# Altium schematic sheet Supressor.SchDoc
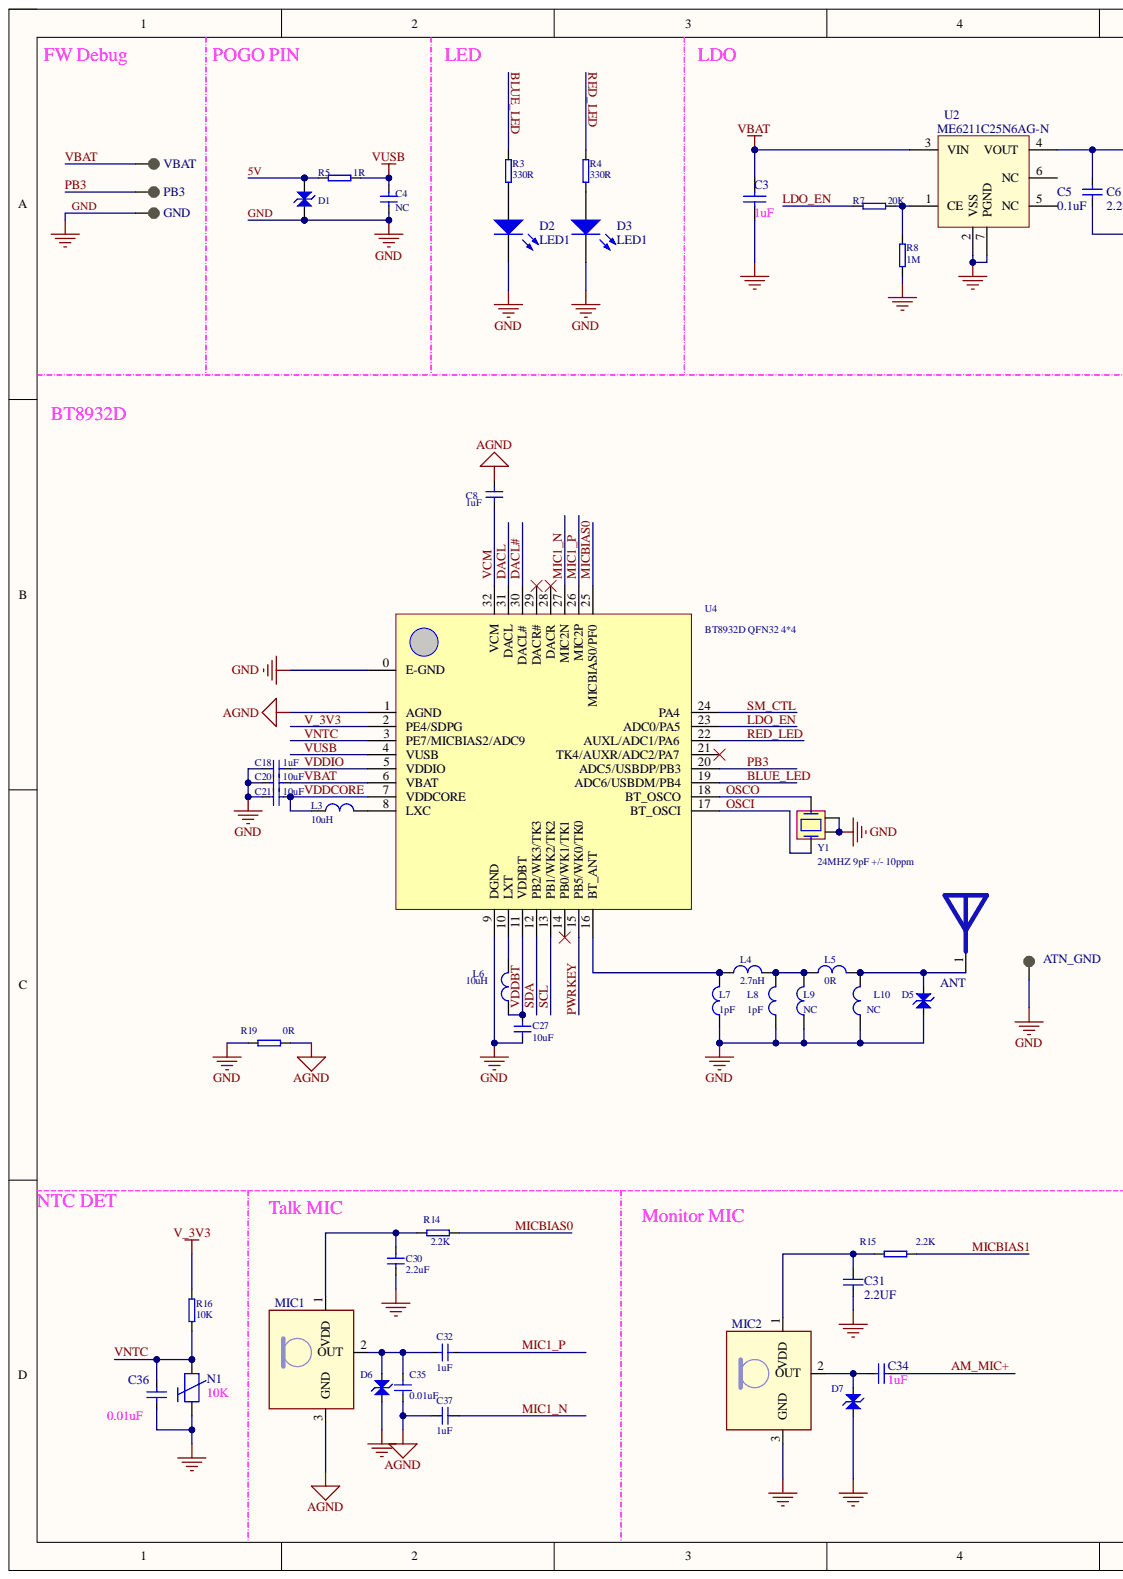
[diagram p0: Supressor.SchDoc, left]
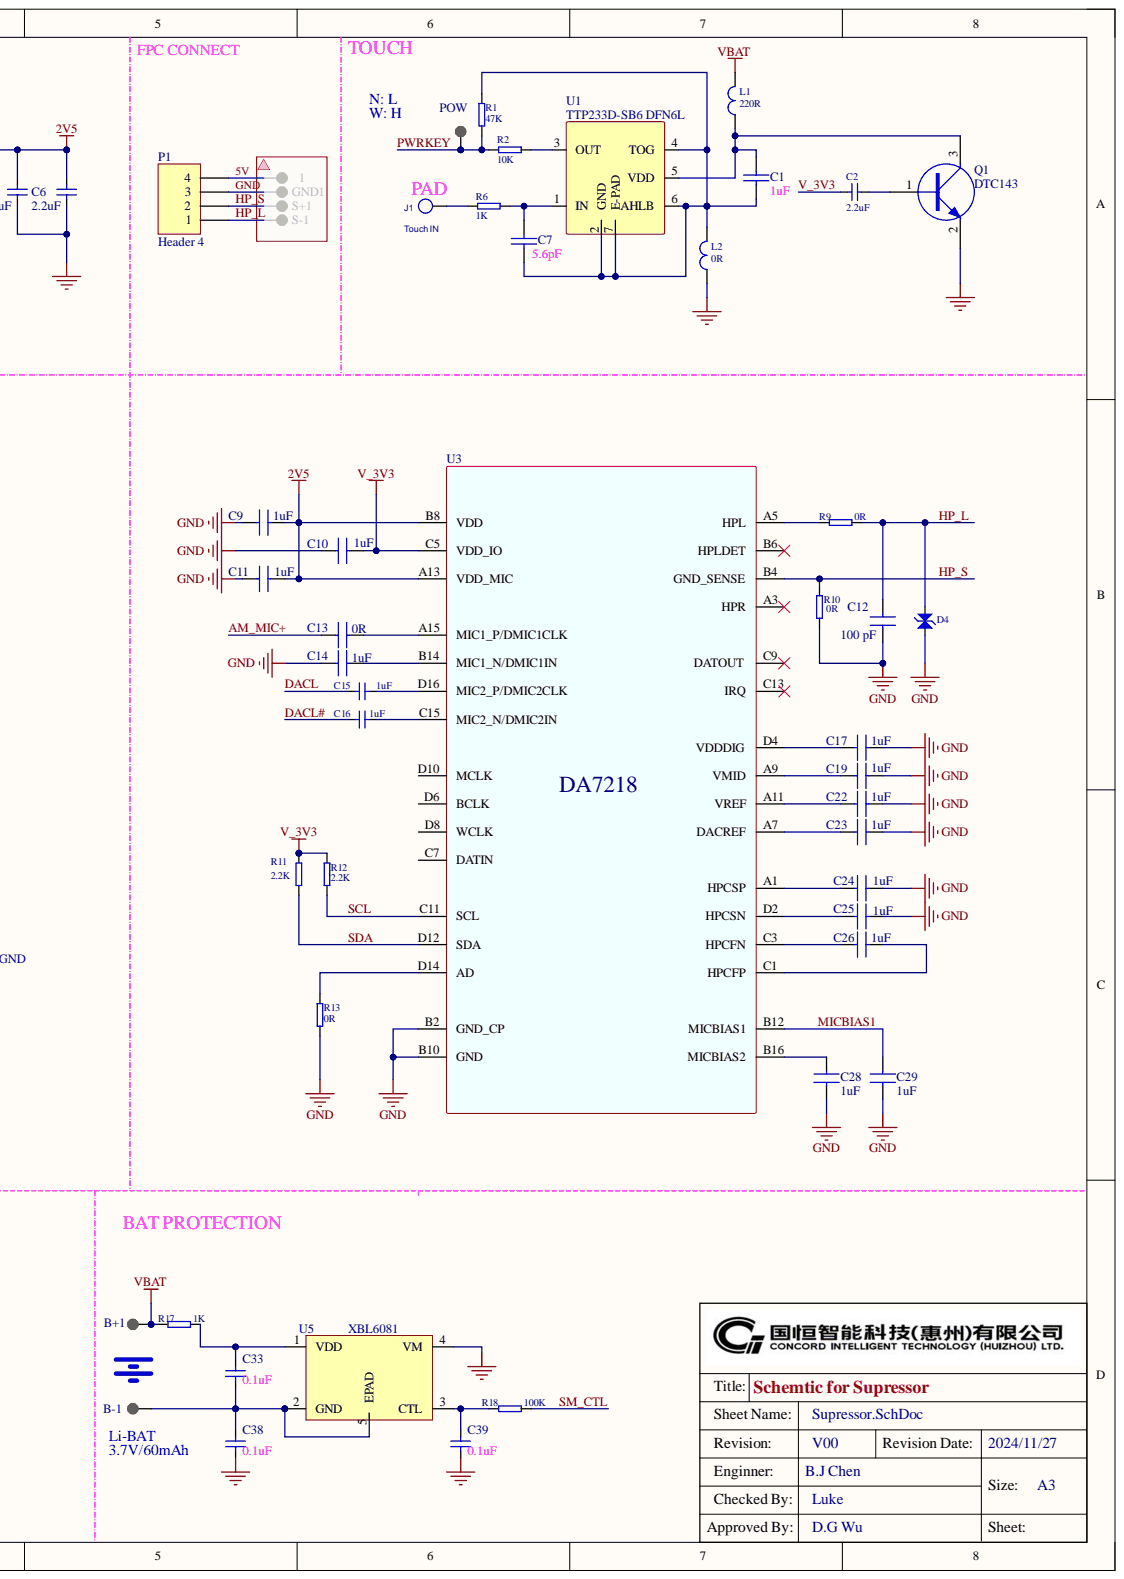
[diagram p1: Supressor.SchDoc, right]

## Parts
ANT  pogopin  [POGO PING-1.5]  (value not drawn)
ATN_GND  pogopin  [POGO PING-1.5]  (value not drawn)
B+1  TP  [TP-1.0]  (value not drawn)
B-1  TP  [TP-1.0]  (value not drawn)
C1  1uF  [CMA0201_CAP]
C2  2.2uF  [CMA0201_CAP]
C3  value 1uF  [CMA0201_CAP]  (hidden comment: 102-1105620B2)
C4  NC  [CMA0201_CAP]
C5  0.1uF 0201  [CMA0201_CAP]  (value not drawn)
C6  2.2uF 0201  [CMA0201_CAP]  (value not drawn)
C7  5.6pF  [CMA0201_CAP]
C8  1uF  [CMA0201_CAP]
C9  value 1uF  [CMA0201_CAP]  (hidden comment: 102-1105710B2)
C10  Part Number: 1uF  [CMA0201_CAP]  (hidden comment: 102-1105710B2)
C11  Part Number: 1uF  [CMA0201_CAP]  (hidden comment: 102-1105710B2)
C12  100 pF  [CMA0201_CAP]
C13  Part Number: 0R  [CMA0201_CAP]  (hidden comment: 102-1105710B2)
C14  Part Number: 1uF  [CMA0201_CAP]  (hidden comment: 102-1105710B2)
C15  1uF  [CMA0201_CAP]
C16  1uF  [CMA0201_CAP]
C17  Part Number: 1uF  [CMA0201_CAP]  (hidden comment: 102-1105710B2)
C18  1uF  [CMA0201_CAP]
C19  Part Number: 1uF  [CMA0201_CAP]  (hidden comment: 102-1105710B2)
C20  10uF  [CMA0402_CAP]
C21  10uF  [CMA0402_CAP]
C22  Part Number: 1uF  [CMA0201_CAP]  (hidden comment: 102-1105710B2)
C23  Part Number: 1uF  [CMA0201_CAP]  (hidden comment: 102-1105710B2)
C24  Part Number: 1uF  [CMA0201_CAP]  (hidden comment: 102-1105710B2)
C25  Part Number: 1uF  [CMA0201_CAP]  (hidden comment: 102-1105710B2)
C26  Part Number: 1uF  [CMA0201_CAP]  (hidden comment: 102-1105710B2)
C27  10uF  [CMA0402_CAP]
C28  Part Number: 1uF  [CMA0201_CAP]  (hidden comment: 102-1105710B2)
C29  Part Number: 1uF  [CMA0201_CAP]  (hidden comment: 102-1105710B2)
C30  2.2uF  [CMA0201_CAP]
C31  2.2UF  [CMA0201_CAP]
C32  1uF  [CMA0201_CAP]
C33  0.1uF  [CMA0201_CAP]
C34  1uF  [CMA0201_CAP]
C35  0.01uF  [CMA0201_CAP]
C36  0.01uF  [CMA0201_CAP]
C37  1uF  [CMA0201_CAP]
C38  0.1uF  [CMA0201_CAP]
C39  0.1uF  [CMA0201_CAP]
D1  104-0006210A2  [SOD-882(ESD)]  (value not drawn)
D2  LED1  [0201-LED-BLUE]
D3  LED1  [0201-LED -RED]
D4  104-0006210A2  [SOD-882(ESD)]  (value not drawn)
D5  104-0006210A2  [SOD-882(ESD)]  (value not drawn)
D6  104-0006210A2  [SOD-882(ESD)]  (value not drawn)
D7  104-0006210A2  [SOD-882(ESD)]  (value not drawn)
GND  TP  [TP-0.6]  (value not drawn)
J1  Touch IN  [POGO PING-1.5]
L1  220R  [CMA0402_RES]
L2  0R  [CMA0201_IND]
L3  10uH  [CMA0603_IND]
L4  2.7nH  [CMA0201_IND]
L5  0R  [CMA0201_IND]
L6  10uH  [CMA0603_IND]
L7  1pF  [CMA0201_IND]
L8  1pF  [CMA0201_IND]
L9  NC  [CMA0201_IND]
L10  NC  [CMA0201_IND]
MIC1  MIC -38dB  [MIC2718B381YR6-ANC]  (value not drawn)
MIC2  MIC -38dB  [MIC2718B381YR6-ANC]  (value not drawn)
N1  10K  [CMA0402_RES - silk]
P1  Header 4  [CON-FPC - duplicate]
PB3  value PB3  [TP-0.6]  (hidden comment: TP)
POW  value POW  [TP-0.6]  (hidden comment: TP)
Q1  DTC143  [SOT-723]
R1  47K  [CMA0201_RES]
R2  10K  [CMA0201_RES]
R3  330R  [CMA0201_RES]
R4  330R  [CMA0201_RES]
R5  1R  [CMA0402_RES - silk]
R6  1K  [CMA0201_RES]
R7  20K  [CMA0201_RES]
R8  1M  [CMA0201_RES]
R9  0R  [CMA0201_RES]
R10  0R  [CMA0201_RES]
R11  2.2K  [CMA0201_RES]
R12  2.2K  [CMA0201_RES]
R13  0R  [CMA0201_RES]
R14  2.2K  [CMA0201_RES]
R15  2.2K  [CMA0201_RES]
R16  10K  [CMA0201_RES]
R17  1K  [CMA0201_RES]
R18  100K  [CMA0201_RES]
R19  0R  [CMA0402_RES - silk]
U1  value TTP233D-SB6 DFN6L  [DFN 2X6]  (hidden comment: 105-0023360B2)
U2  ORDERING CODE: ME6211C25N6AG-N  [DFN 2X6]  (hidden comment: 105-2462110C2)
U3  value DA7218  [DA7818]  (hidden comment: 105-0072180A2)
U4  BT8932D QFN32 4*4  [Quad32]
U5  value XBL6081  [IC-QFN-04-1X1]  (hidden comment: 105-0023220A2)
VBAT  value VBAT  [TP-0.6]  (hidden comment: TP)
Y1  24MHZ 9pF +/- 10ppm  [CRY-2016]

## Nets
2V5: C5.2 C6.2 C9.2 C11.2 U2.4(VOUT) U3.A13(VDD_MIC) U3.B8(VDD)
AGND: C8.2 C35.2 C37.2 MIC1.3 R19.2 U4.1(AGND)
5V: D1.2 P1.4 R5.1
AM_MIC+: C13.1 C34.2
BLUE_LED: R3.1 U4.19(ADC6/USBDM/PB4)
DACL: C15.2 U4.31(DACL)
DACL#: C16.2 U4.30(DACL#)
GND: ATN_GND.1 C3.2 C4.1 C5.1 C6.1 C9.1 C10.1 C11.1 C12.1 C14.1 C17.2 C18.2 C19.2 C20.2 C21.2 C22.2 C23.2 C24.2 C25.2 C27.1 C28.1 C29.2 C30.1 C31.1 C36.2 C38.2 C39.2 D1.1 D2.2 D3.2 D4.2 D5.2 D6.1 D7.1 GND.1 L2.1 L7.1 L8.1 L9.1 L10.1 MIC2.3 N1.1 P1.3 Q1.2 R8.1 R10.1 R13.1 R19.1 U2.2(VSS) U2.7(PGND) U3.B2(GND_CP) U3.B10(GND) U4.0(E-GND) U4.9(DGND) U5.4(VM) Y1.2 Y1.4
HP_L: C12.2 D4.1 P1.1 R9.2
HP_S: P1.2 R10.2 U3.B4(GND_SENSE)
LDO_EN: R7.1 U4.23(ADC0/PA5)
MIC1_N: C37.1 U4.27(MIC2N)
MIC1_P: C32.1 U4.26(MIC2P)
MICBIAS0: R14.1 U4.25(MICBIAS0/PF0)
MICBIAS1: C29.1 R15.2 U3.B12(MICBIAS1)
OSCI: U4.17(BT_OSCI) Y1.1
OSCO: U4.18(BT_OSCO) Y1.3
PB3: PB3.1 U4.20(ADC5/USBDP/PB3)
PWRKEY: POW.1 R1.1 R2.1 U4.15(PB5/WK0/TK0)
RED_LED: R4.1 U4.22(AUXL/ADC1/PA6)
SCL: R12.1 U3.C11(SCL) U4.13(PB1/WK2/TK2)
SDA: R11.1 U3.D12(SDA) U4.12(PB2/WK3/TK3)
SM_CTL: R18.2 U4.24(PA4)
V_3V3: C2.1 C10.2 R11.2 R12.2 R16.1 U3.C5(VDD_IO) U4.2(PE4/SDPG)
VBAT: B+1.1 C3.1 C20.1 L1.2 R17.1 U2.3(VIN) U4.6(VBAT) VBAT.1
VCM: C8.1 U4.32(VCM)
VDDBT: C27.2 L6.1 U4.11(VDDBT)
VDDCORE: C21.1 L3.1 U4.7(VDDCORE)
VDDIO: C18.1 U4.5(VDDIO)
VNTC: C36.1 N1.2 R16.2 U4.3(PE7/MICBIAS2/ADC9)
VUSB: C4.2 R5.2 U4.4(VUSB)
NetANT_1: ANT.1 D5.1 L5.2 L10.2
NetB-1_1: B-1.1 C33.2 C38.1 U5.2(GND) U5.5(EPAD)
NetC1_1: C1.1 C7.1 L2.2 R1.2 U1.2(GND) U1.4(TOG) U1.6(AHLB) U1.7(E-PAD)
NetC1_2: C1.2 L1.1 Q1.3 U1.5(VDD)
NetC2_2: C2.2 Q1.1
NetC7_2: C7.2 R6.2 U1.1(IN)
NetC13_2: C13.2 U3.A15(MIC1_P/DMIC1CLK)
NetC14_2: C14.2 U3.B14(MIC1_N/DMIC1IN)
NetC15_1: C15.1 U3.D16(MIC2_P/DMIC2CLK)
NetC16_1: C16.1 U3.C15(MIC2_N/DMIC2IN)
NetC17_1: C17.1 U3.D4(VDDDIG)
NetC19_1: C19.1 U3.A9(VMID)
NetC22_1: C22.1 U3.A11(VREF)
NetC23_1: C23.1 U3.A7(DACREF)
NetC24_1: C24.1 U3.A1(HPCSP)
NetC25_1: C25.1 U3.D2(HPCSN)
NetC26_1: C26.1 U3.C3(HPCFN)
NetC26_2: C26.2 U3.C1(HPCFP)
NetC28_2: C28.2 U3.B16(MICBIAS2)
NetC30_2: C30.2 MIC1.1 R14.2
NetC31_2: C31.2 MIC2.1 R15.1
NetC32_2: C32.2 C35.1 D6.2 MIC1.2
NetC33_1: C33.1 R17.2 U5.1(VDD)
NetC34_1: C34.1 D7.2 MIC2.2
NetC39_1: C39.1 R18.1 U5.3(CTL)
NetD2_1: D2.1 R3.2
NetD3_1: D3.1 R4.2
NetJ1_1: J1.1 R6.1
NetL3_2: L3.2 U4.8(LXC)
NetL4_1: L4.1 L7.2 U4.16(BT_ANT)
NetL4_2: L4.2 L5.1 L8.2 L9.2
NetL6_2: L6.2 U4.10(LXT)
NetR2_2: R2.2 U1.3(OUT)
NetR7_2: R7.2 R8.2 U2.1(CE)
NetR9_1: R9.1 U3.A5(HPL)
NetR13_2: R13.2 U3.D14(AD)

Not connected: U2 5(NC) 6(NC); U3 A3(HPR) B6(HPLDET) C7(DATIN) C9(DATOUT) C13(IRQ) D6(BCLK) D8(WCLK) D10(MCLK); U4 14(PB0/WK1/TK1) 21(TK4/AUXR/ADC2/PA7) 28(DACR) 29(DACR#)

## Library data of the parts
ANT = ANT3: NA; Component Kind: Standard; Library Name: Supressor PCB_Project.SCHLIB
ATN_GND = TP_2: TEST POINT; Component Kind: Standard; Library Name: Supressor PCB_Project.SCHLIB
B+1 B-1 GND PB3 POW VBAT = TP: TEST POINT; Component Kind: Standard; Library Name: 複製 -SCH.lib
C1 C7 = CAP0402: Component Kind: Standard; Library Name: MySchlib.SchLib
  C1: Value: 1uF
  C7: Value: 5.6pF
C2 C4-C6 C8 C15 C16 C18 C20 C21 C27 C30 C32 C35 C37 = CAP: Capacitor; Component Kind: Standard
  C5 C6: Library Name: 複製 -SCH.lib
C3 = CAP: CER CAP SM 1uF +/-10% 0402 10V X5R; Component Kind: Standard; Library Name: Miscellaneous Devices.IntLib; Published: 8-Jun-2000; Revision: July-2002: Re-released for DXP Platform.; Signal Integrity: Cap; Simulation: CAP; Publisher: Altium Limited
C9-C14 C17 C19 C22-C26 C28 C29 = CAP: CER CAP SM 1uF +/-20% 0201 6.3V X5R CL03A105MQ3CSNC; Component Kind: Standard; Library Name: Silencer TWS.SchLib
  C12: Part Number: 100 pF
C31 C34 = CAP: CER CAP SM 1uF +/-20% 0201 6.3V X5R; Component Kind: Standard; Library Name: 複製 -SCH.lib
  C34: Value: 1uF
C33 C38 C39 = CAP: CER CAP SM 0.1uF +/-10% 0201 10V X5R; Component Kind: Standard; Value: 0.1uF; Library Name: 複製 -SCH.lib
C36 = CAP: CER CAP SM 10nF +/-10% 0201 16V X7R; Component Kind: Standard; Value: 0.01uF; Library Name: 複製 -SCH.lib
D1 D4-D7 = SMB/DO-214AA P6SMB6.8CA: DIO SM ESD PROTECTION DIODES PTN062G15M3B18 DFN0603(0201); Component Kind: Standard; ComponentLink1Description: 供应商链接; ComponentLink1URL: http://www.szlcsc.com/product/details_79530.html; Package: SMB/DO-214AA; Supplier: LC; SuppliersPartNumber: C78395; Library Name: BT8892A QFN32_4x4 TWS蓝牙耳机V1.4.SCHLIB
D2 D3 = LED1: Typical RED GaAs LED; Component Kind: Standard; LatestRevisionDate: 08-Jul-2005; LatestRevisionNote: Mech Layer 1 removed.; Library Name: Miscellaneous Devices.IntLib; PackageDescription: LED; 2 Leads; PackageReference: LED-1; Published: 8-Jun-2000; Simulation: LED1; Publisher: Altium Limited
J1 = PT: OriginalSymbolOrigin: (0,0); Assembly option: Touch IN; Component Kind: Standard
L1 L2 L4 L5 L7-L10 = INDUCTOR: Component Kind: Standard
L3 L6 = INDUCTOR: 叠层贴片电感; Component Kind: Standard
MIC1 MIC2 = MIC2718: Component Kind: Standard; Description: MIC -38dB; Library Name: LBJiang.SchLib
N1 = NTC: NTC THERMISTORS SM 10K OHM +/-1% 0402 0.07W NTCG103JF103FT1; Component Kind: Standard; Value: 10K; Library Name: 複製 -SCH.lib
P1 = Header 4: Header, 4-Pin; Component Kind: Standard; LatestRevisionDate: 17-Jul-2002; LatestRevisionNote: Re-released for DXP Platform.; Library Name: Miscellaneous Connectors.IntLib; Signal Integrity: Connector; Publisher: Altium Limited
Q1 = MMBT3904: NPN; Component Kind: Standard; Value: MMBT3904; Library Name: HAYLOU S35.SCHLIB
R1-R19 = RES2: Component Kind: Standard
U1 = IC-TTP233D-SB6: DIC SM TOUCH CHIPSET TTP233D-SB6 DFN6L; Component Kind: Standard; Library Name: 複製 -SCH.lib
U2 = IC-ME6211C25N6AG-N: AIC SM 2.5V/500mA LDO REGULATORS ME6211C25N6AG-N DFN2x2-6L; Component Kind: Standard; PN: 105-2462110C2; Library Name: 複製 -SCH.lib
U3 = DA7217: DIC SM POWER STEREO CODEC DA7218-00U32 WL-CSP32; Component Kind: Standard; Library Name: Supressor PCB_Project_1.SCHLIB
U4 = BT8932E QFN32 4*4: Component Kind: Standard; Description: BT8932D QFN32 4*4; Library Name: BT8932E QFN32_4x4 TWS蓝牙耳机 V0.1.SCHLIB
U5 = IC-WSDF23A2N2H: AIC SM PROTECTION POLYMER BATTERY WSDF23A2N2H DFN4L_1x1; Component Kind: Standard; PN: 105-0023220A2; Library Name: 複製 -SCH.lib
Y1 = 26MHZ: 107-0102441B2; Component Kind: Standard
